# Altium schematic sheet PMSM_500W_Controller_Driver.SchDoc
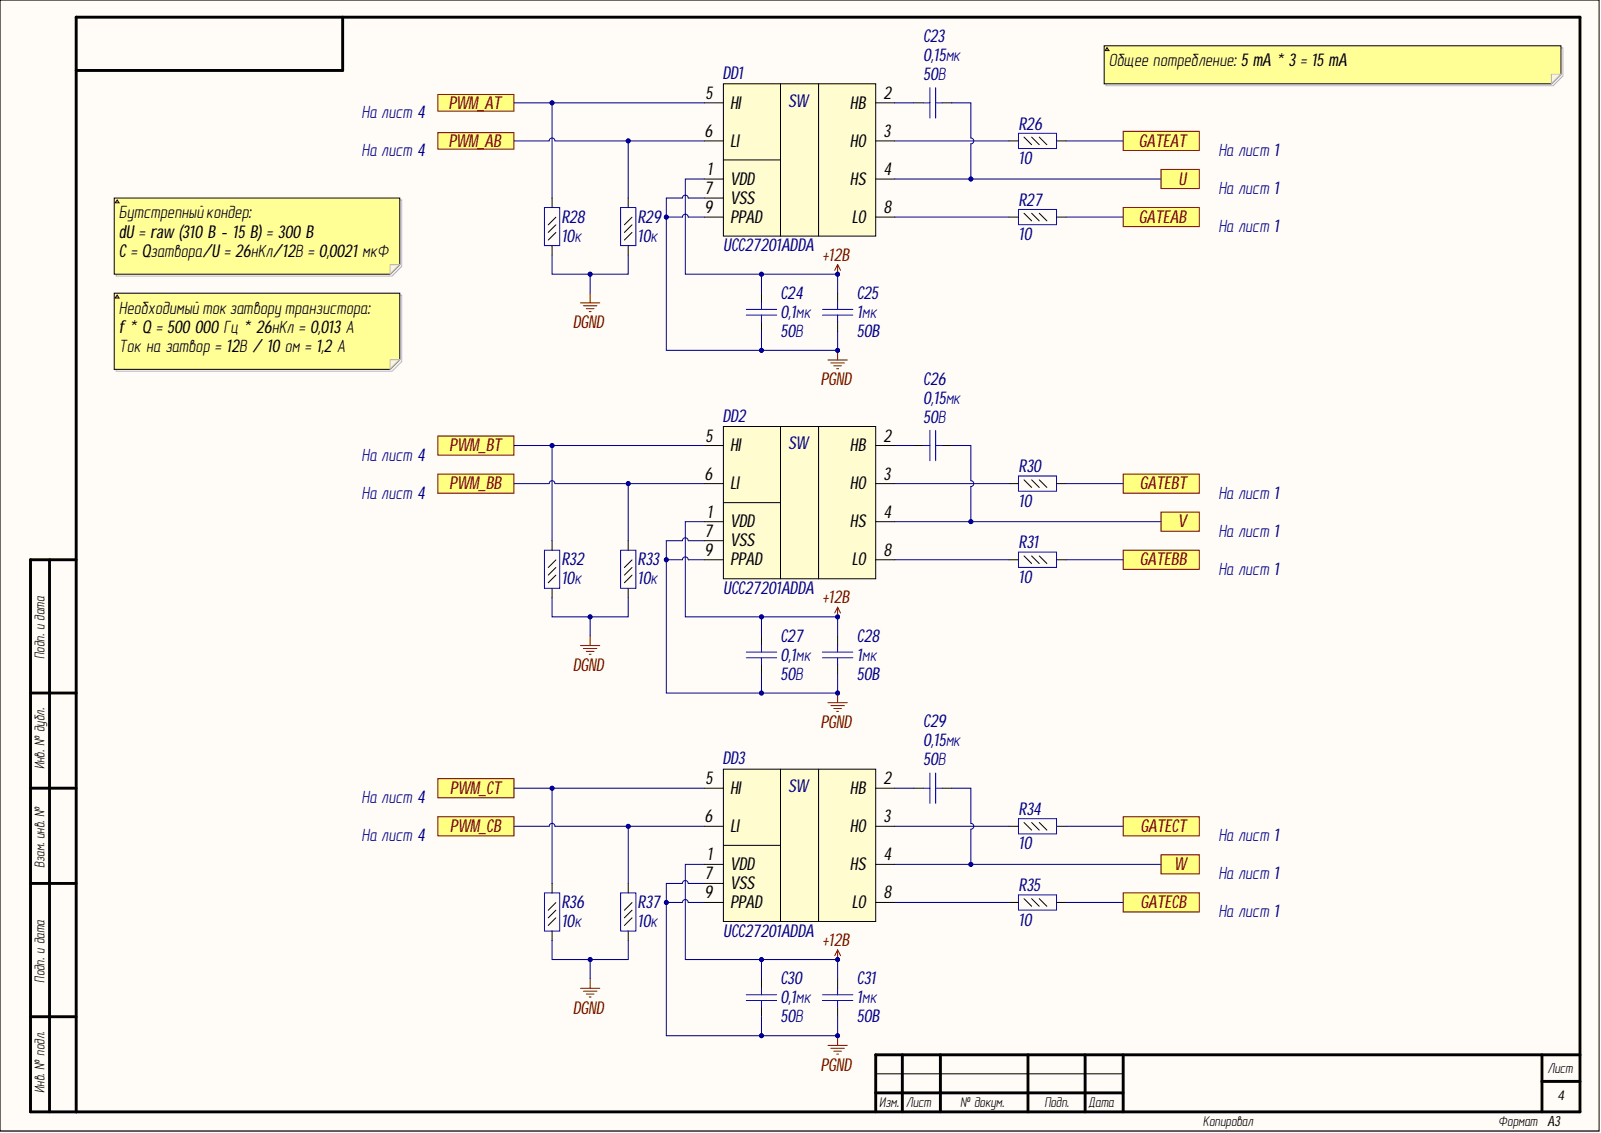
[diagram p0: PMSM_500W_Controller_Driver.SchDoc]

## Parts
C23  0,15мк  Voltage: 50В  [C1812]
C24  value 0,1мк  Voltage: 50В  [C0402]  (hidden comment: Capacitor)
C25  value 1мк  Voltage: 50B  [C0805]  (hidden comment: Capacitor)
C26  0,15мк  Voltage: 50В  [C1812]
C27  value 0,1мк  Voltage: 50В  [C0402]  (hidden comment: Capacitor)
C28  value 1мк  Voltage: 50B  [C0805]  (hidden comment: Capacitor)
C29  0,15мк  Voltage: 50В  [C1812]
C30  value 0,1мк  Voltage: 50В  [C0402]  (hidden comment: Capacitor)
C31  value 1мк  Voltage: 50B  [C0805]  (hidden comment: Capacitor)
DD1  UCC27201A  Part Number: UCC27201ADDA  [SO8 POWERPAD]
DD2  UCC27201A  Part Number: UCC27201ADDA  [SO8 POWERPAD]
DD3  UCC27201A  Part Number: UCC27201ADDA  [SO8 POWERPAD]
R26  value 10  [R0402]  (hidden comment: Resistor_0,062Вт)
R27  value 10  [R0402]  (hidden comment: Resistor_0,062Вт)
R28  value 10к  [R0402]  (hidden comment: Resistor_0,062Вт)
R29  value 10к  [R0402]  (hidden comment: Resistor_0,062Вт)
R30  value 10  [R0402]  (hidden comment: Resistor_0,062Вт)
R31  value 10  [R0402]  (hidden comment: Resistor_0,062Вт)
R32  value 10к  [R0402]  (hidden comment: Resistor_0,062Вт)
R33  value 10к  [R0402]  (hidden comment: Resistor_0,062Вт)
R34  value 10  [R0402]  (hidden comment: Resistor_0,062Вт)
R35  value 10  [R0402]  (hidden comment: Resistor_0,062Вт)
R36  value 10к  [R0402]  (hidden comment: Resistor_0,062Вт)
R37  value 10к  [R0402]  (hidden comment: Resistor_0,062Вт)

## Ports
GATEAB, GATEAT, GATEBB, GATEBT, GATECB, GATECT, PWM_AB, PWM_AT, PWM_BB, PWM_BT, PWM_CB, PWM_CT, U, V, W

## Nets
+12B: C24.2 C25.2 C27.2 C28.2 C30.2 C31.2 DD1.1(VDD) DD2.1(VDD) DD3.1(VDD)
DGND: R28.1 R29.1 R32.1 R33.1 R36.1 R37.1
PGND: C24.1 C25.1 C27.1 C28.1 C30.1 C31.1 DD1.7(VSS) DD1.9(PPAD) DD2.7(VSS) DD2.9(PPAD) DD3.7(VSS) DD3.9(PPAD)
GATEAB: R27.2 ; port GATEAB
GATEAT: R26.2 ; port GATEAT
GATEBB: R31.2 ; port GATEBB
GATEBT: R30.2 ; port GATEBT
GATECB: R35.2 ; port GATECB
GATECT: R34.2 ; port GATECT
PWM_AB: DD1.6(LI) R29.2 ; port PWM_AB
PWM_AT: DD1.5(HI) R28.2 ; port PWM_AT
PWM_BB: DD2.6(LI) R33.2 ; port PWM_BB
PWM_BT: DD2.5(HI) R32.2 ; port PWM_BT
PWM_CB: DD3.6(LI) R37.2 ; port PWM_CB
PWM_CT: DD3.5(HI) R36.2 ; port PWM_CT
U: C23.2 DD1.4(HS) ; port U
V: C26.2 DD2.4(HS) ; port V
W: C29.2 DD3.4(HS) ; port W
NetC23_1: C23.1 DD1.2(HB)
NetC26_1: C26.1 DD2.2(HB)
NetC29_1: C29.1 DD3.2(HB)
NetDD1_3: DD1.3(HO) R26.1
NetDD1_8: DD1.8(LO) R27.1
NetDD2_3: DD2.3(HO) R30.1
NetDD2_8: DD2.8(LO) R31.1
NetDD3_3: DD3.3(HO) R34.1
NetDD3_8: DD3.8(LO) R35.1

## Library data of the parts
C23 C26 C29 = cap285: Конденсатор; Manufacture: TDK; Part Name: Ceramic; Part Number: C4532C0G1H154J250KA; Database Table Name: Capacitors$; TKE: NP0; Component Kind: Standard; id: cap285; Library Name: MOP44.DbLib; Value: 0,15мк
C24 C27 C30 = cap277: Конденсатор; Manufacture: Murata; Part Name: Ceramic; Part Number: GRM155R71H104KE14D; Database Table Name: Capacitors$; tga: 0,10%; TKE: X7R; Component Kind: Standard; id: cap277; Library Name: MOP44.DbLib
C25 C28 C31 = cap151: Конденсатор; Manufacture: Murata; Part Name: Ceramic; Part Number: GRM21BR71H105K; Database Table Name: Capacitors$; TKE: X7R; Component Kind: Standard; id: cap151; Library Name: MOP44.DbLib
DD1-DD3 = driver25: 120-V Boot, 3-A Peak, High-Frequency, High-Side and Low-Side Driver; Manufacture: Texas Instruments; Part Name: High-Side/Low-Side Driver; Database Table Name: Driver$; Logic High: 1,6B-2,5B; Logic Low: 0,8B-1,6B; Max Operating Supply Voltage: 17B; Maximum output current: 3A; Maximum Power Dissipation: 2,7W; Min Operating Supply Voltage: 8B; Vbus: 120B; “A” OUTPUT: Noninverting; Component Kind: Standard; id: driver25; Library Name: MOP44.DbLib; “B” OUTPUT: Noninverting
R26 R27 R30 R31 R34 R35 = res222: Резистор; Manufacture: Yageo; Part Number: RC0402FR-0710RL; Accuracy: 0,01; Database Table Name: Resistor$; Component Kind: Standard; id: res222; Library Name: MOP44.DbLib; Part Name: Resistor; Power: 0,062Вт
R28 R29 R32 R33 R36 R37 = res294: Резистор; Manufacture: Yageo; Part Number: RC0402FR-0710KL; Accuracy: 0,01; Database Table Name: Resistor$; Component Kind: Standard; id: res294; Library Name: MOP44.DbLib; Part Name: Resistor; Power: 0,062Вт
